# Altium schematic sheet NXP NXPhlite-IRDA SDCARD 3.0RC18.SchDoc
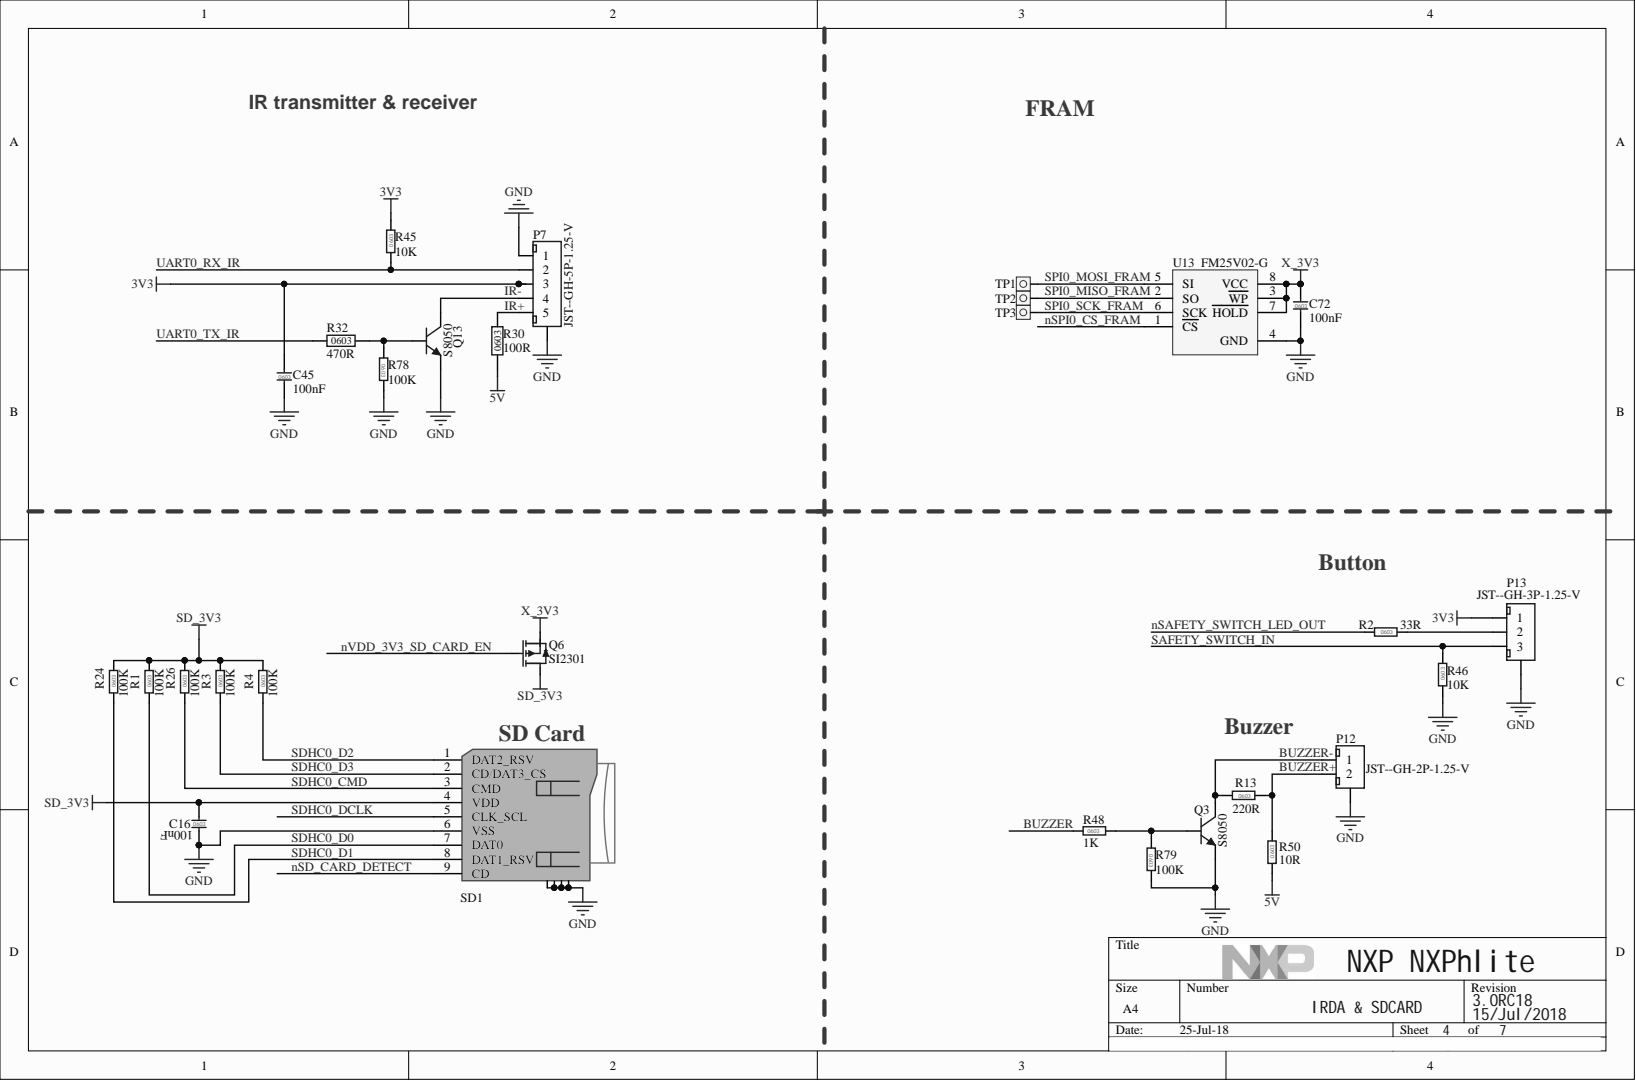
[diagram p0: NXP NXPhlite-IRDA SDCARD 3.0RC18.SchDoc]

## Parts
C16  100nF  [0603C]
C45  100nF  [0603C]
C72  100nF  [0603C]
P7  JST--GH-5P-1.25-V  [JST-5P-1.25]
P12  JST--GH-2P-1.25-V  [JST-2P-1.25]
P13  JST--GH-3P-1.25-V  [JST-3P-1.25]
Q3  S8050  [SOT-23]
Q6  SI2301  [SOT-23]
Q13  S8050  [SOT-23]
R1  100K  [0603R]
R2  33R  [0603R]
R3  100K  [0603R]
R4  100K  [0603R]
R13  220R  [0603R]
R24  100K  [0603R]
R26  100K  [0603R]
R30  100R  [0603R]
R32  470R  [0603R]
R45  10K  [0603R]
R46  10K  [0603R]
R48  1K  [0603R]
R50  10R  [0603R]
R78  100K  [0603R]
R79  100K  [0603R]
SD1  MicroSD  [MicroSD_Push]  (value not drawn)
TP1  TP  [TP_0.8]
TP2  TP  [TP_0.8]
TP3  TP  [TP_0.8]
U13  FM25V02-G  [SO-8_N]

## Nets
3V3: C45.1 P7.3 P13.1 R45.1
5V: R30.1 R50.2
GND: C16.2 C45.2 C72.2 P7.0 P7.1 P12.0 P13.0 Q3.2 Q13.2 R46.2 R78.1 R79.1 SD1.6(VSS) SD1.10 U13.4(GND)
SD_3V3: C16.1 Q6.3 R1.1 R3.1 R4.1 R24.1 R26.1 SD1.4(VDD)
X_3V3: C72.1 Q6.2 U13.3(WP) U13.7(HOLD) U13.8(VCC)
BUZZER: R48.1
BUZZER+: P12.2 R13.1 R50.1
BUZZER-: P12.1 Q3.3 R13.2
IR+: P7.5 R30.2
IR-: P7.4 Q13.3
nSAFETY_SWITCH_LED_OUT: R2.2
nSD_CARD_DETECT: SD1.9(CD)
nSPI0_CS_FRAM: U13.1(CS)
nVDD_3V3_SD_CARD_EN: Q6.1
SAFETY_SWITCH_IN: P13.3 R46.1
SDHC0_CMD: R26.2 SD1.3(CMD)
SDHC0_D0: R1.2 SD1.7(DAT0)
SDHC0_D1: R24.2 SD1.8(DAT1_RSV)
SDHC0_D2: R4.2 SD1.1(DAT2_RSV)
SDHC0_D3: R3.2 SD1.2(CD/DAT3_CS)
SDHC0_DCLK: SD1.5(CLK_SCL)
SPI0_MISO_FRAM: TP2.1 U13.2(SO)
SPI0_MOSI_FRAM: TP1.1 U13.5(SI)
SPI0_SCK_FRAM: TP3.1 U13.6(SCK)
UART0_RX_IR: P7.2 R45.2
UART0_TX_IR: R32.1
NetP13_2: P13.2 R2.1
NetQ3_1: Q3.1 R48.2 R79.2
NetQ13_1: Q13.1 R32.2 R78.2

## Library data of the parts
C16 C45 C72 = Cap_0603: CAP CER 0.1uF 16V 10% X7R AEC-Q200 0603; Manufacturer: MURATA; Manufacturer PN: GCM188R71C104KA37D; Agile PN: 150-79338; Component Kind: Standard; Library Name: LZ SchLib V1.0.SchLib
P7 = XH2.54_5P: CONN HEADER GH TOP 5POS 1.25MM; Manufacturer: JST Sales America Inc; Manufacturer PN: BM05B-GHS-TBT(LF)(SN)(N); Agile PN: TBD; Component Kind: Standard; Library Name: NXP FMU V2.4.SCHLIB
P12 = XH2.54_2P: CONN HEADER GH TOP 2POS 1.25MM; Manufacturer: JST Sales America Inc; Manufacturer PN: BM02B-GHS-TBT(LF)(SN)(N); Agile PN: TBD; Component Kind: Standard; Library Name: NXP NXPhlite V3.0.SCHLIB
P13 = XH2.54_3P: CONN HEADER GH TOP 3POS 1.25MM; Manufacturer: JST Sales America Inc; Manufacturer PN: BM03B-GHS-TBT(LF)(SN)(N); Agile PN: TBD; Component Kind: Standard; Library Name: NXP NXPhlite V3.0.SCHLIB
Q3 Q13 = 8050_NPN: TRANS NPN 25V 1.5A SOT23; Manufacturer: Micro Commercial Components; Manufacturer PN: MMSS8050; Agile PN: TBD; Component Kind: Standard; Library Name: SchLib(2).SchLib
Q6 = MOSFET-P: MOSFET P-CH 20V 3.1A SOT23-3; Manufacturer: VISHAY INTERTECHNOLOGY; Manufacturer PN: Si2301CDS; Agile PN: TBD; Component Kind: Standard; Simulation: PMOS; Library Name: NXP NXPhlite V3.2.SCHLIB
R1 R3 R4 R24 R26 R78 R79 = Res_0603: RES MF 100K 1/10W 1% AEC-Q200 0603; Manufacturer: KOA SPEER; Manufacturer PN: RK73H1JTTD1003F; Agile PN: 470-81842; Component Kind: Standard; Library Name: LZ SchLib V1.0.SchLib
R2 = Res_0603: RES MF 33 OHM 1/8W 1% AEC-Q200 0603; Manufacturer: KOA SPEER; Manufacturer PN: RK73H1JTTD33R0F; Agile Pn: 470-81926; Component Kind: Standard; Library Name: LZ SchLib V1.0.SchLib
R13 = Res_0603: RES MF 220 OHM 1/8W 1% AEC-Q200 0603; Manufacturer: KOA SPEER; Manufacturer PN: RK73H1JTTD2200F; Agile PN: 470-81342; Component Kind: Standard; Library Name: LZ SchLib V1.0.SchLib
R30 = Res_0603: RES MF 100 OHM 1/8W 1% AEC-Q200 0603; Manufacturer: KOA SPEER; Manufacturer PN: RK73H1JTTD1000F; Agile PN: 470-82000; Component Kind: Standard; Library Name: SchLib(2).SchLib
R32 = Res_0603: RES MF 470.0 OHM 1/8W 1% AEC-Q200 0603; Manufacturer: KOA SPEER; Manufacturer PN: RK73H1JTTD4700F; Agile PN: 470-81738; Component Kind: Standard; Library Name: SchLib(2).SchLib
R45 R46 = Res_0603: RES MF 10.0K 1/10W 1% 0603; Manufacturer: Yageo; Manufacturer PN: RC0603FR-0710KL; Agile PN: 470-75422; Component Kind: Standard; Library Name: LZ SchLib V1.0.SchLib
R48 = Res_0603: RES MF 1.00K 1/8W 1% AEC-Q200 0603; Manufacturer: KOA SPEER; Manufacturer PN: RK73H1JTTD1001F; Agile PN: 470-81616; Component Kind: Standard; Library Name: LZ SchLib V1.0.SchLib
R50 = Res_0603: RES MF 10.0 OHM 1/10W 1% 0603; Manufacturer: Yageo; Manufacturer PN: RC0603FR-0710RL; Agile PN: 470-75423; Component Kind: Standard; Library Name: LZ SchLib V1.0.SchLib
SD1 = MicroSD1: Manufacturer: MUP; Manufacturer PN: M614; Agile PN: TBD; Component Kind: Standard; Description: MicroSD; Library Name: NXP FMU V2.2.SCHLIB
U13 = AT45DB161D-MU: IC FRAM 256KBIT 40MHZ 8SOIC; Manufacturer: Cypress Semiconductor Corp; Manufacturer PN: FM25V02A-G; Agile PN: TBD; Component Kind: Standard; Library Name: NXP FMU V2.2.SCHLIB
TP1-TP3 = TP: Component Kind: Standard; Library Name: LZ SchLib V1.0.SchLib
